# Altium schematic sheet Status_LEDs.SchDoc
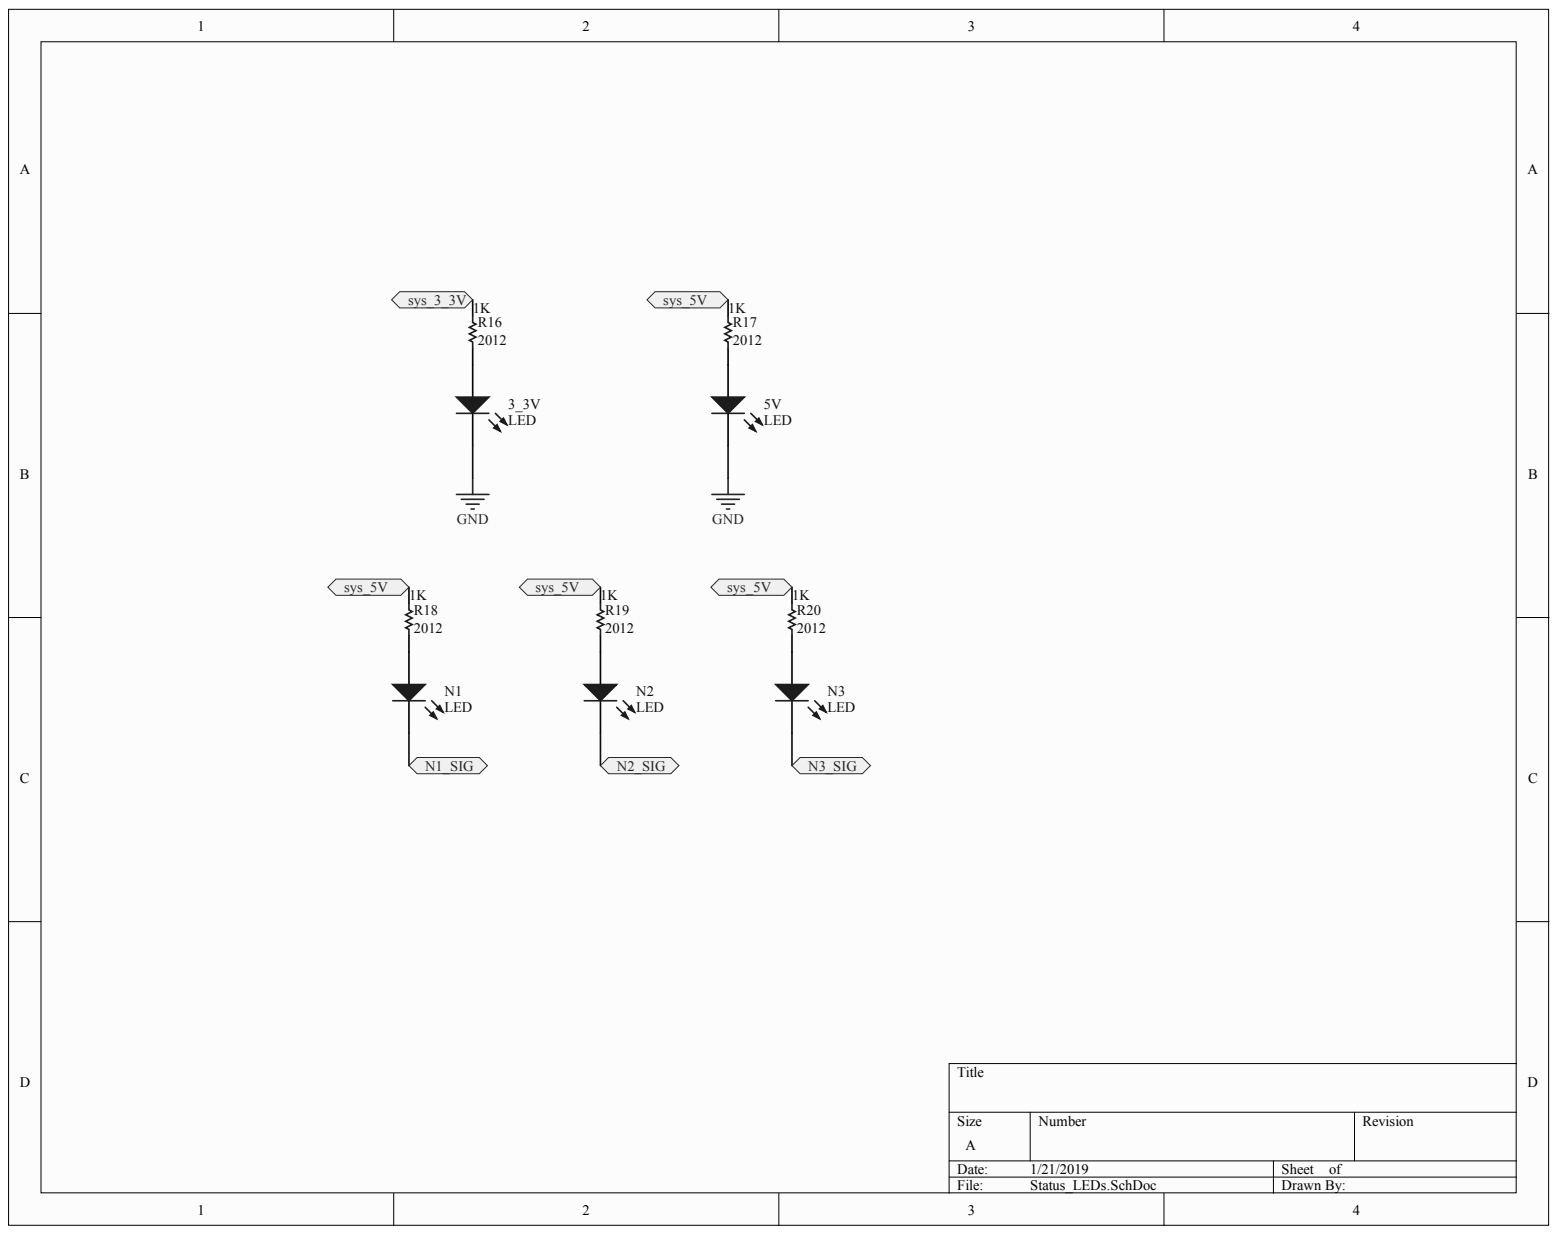
[diagram p0: Status_LEDs.SchDoc]

## Parts
3_3V  LED  [PCB-a4kbstwpa15hyka10z5q-1]
5V  LED  [PCB-a4kbstwpa15hyka10z5q-1]
N1  LED  [PCB-a4kbstwpa15hyka10z5q-1]
N2  LED  [PCB-a4kbstwpa15hyka10z5q-1]
N3  LED  [PCB-a4kbstwpa15hyka10z5q-1]
R16  Case/Package (SI): 2012  Resistance: 1K  [RESC2013X70X40ML20T20]  (hidden comment: Panasonic ERJ-6GEYJ822V)
R17  Case/Package (SI): 2012  Resistance: 1K  [RESC2013X70X40ML20T20]  (hidden comment: Panasonic ERJ-6GEYJ822V)
R18  Case/Package (SI): 2012  Resistance: 1K  [RESC2013X70X40ML20T20]  (hidden comment: Panasonic ERJ-6GEYJ822V)
R19  Case/Package (SI): 2012  Resistance: 1K  [RESC2013X70X40ML20T20]  (hidden comment: Panasonic ERJ-6GEYJ822V)
R20  Case/Package (SI): 2012  Resistance: 1K  [RESC2013X70X40ML20T20]  (hidden comment: Panasonic ERJ-6GEYJ822V)

## Ports
N1_SIG, N2_SIG, N3_SIG, SYS_3_3V, SYS_5V

## Nets
GND: 3_3V.2 5V.2
SYS_3_3V: R16.2 ; port SYS_3_3V
SYS_5V: R17.2 R18.2 R19.2 R20.2 ; port SYS_5V
N1_SIG: N1.2 ; port N1_SIG
N2_SIG: N2.2 ; port N2_SIG
N3_SIG: N3.2 ; port N3_SIG
Net3_3V_1: 3_3V.1 R16.1
Net5V_1: 5V.1 R17.1
NetN1_1: N1.1 R18.1
NetN2_1: N2.1 R19.1
NetN3_1: N3.1 R20.1

## Library data of the parts
3_3V 5V N1-N3 = CMP-afe165a651817026-6: LED; PCB ; Green; 0.63mcd; 3mm; T-1; 7mA; 1.9V; 565nm; 25deg; GaP on GaP; 20V; 20mW; -40; Manufacturer Part Number: TLLG4400; Forward Voltage: 2.40 V; Luminous Intensity: 1.20 mcd; Viewing Angle: 25 °; Color: Green; Component Kind: Standard; Library Name: CommunityVault; Mounting Style: Through Hole, Radial; OctopartID: afe165a651817026; Octopart URL: http://octopart.com/tllg4400-vishay-55433990; Voltage Rating (DC): 2.40 V
R16-R20 = CMP-3687578-2: Thick Film Resistors - SMD 0805 8.2Kohms 5% Tol; Lead-Free Status: Lead Free; Power Rating: 0.125 W; Voltage Rating (DC): 150.0 V; Case/Package: 0805; Component Kind: Standard; Library Name: CommunityVault; Lifecycle Status: Not Listed by Manufacturer; Manufacturer Part Number: ERJ-6GEYJ822V; Mounting Style: Surface Mount; OctopartID: 0556cf11906ada91; Octopart Url: https://octopart.com/erj-6geyj822v-panasonic-55423066; Packaging: Cut Tape (CT); Resistance Tolerance: ±5%; RoHS: Compliant; Size-Height: 0.0006 m; Size-Length: 0.002 m; Size-Width: 0.00125 m
